# Altium schematic sheet Power_supply.SchDoc
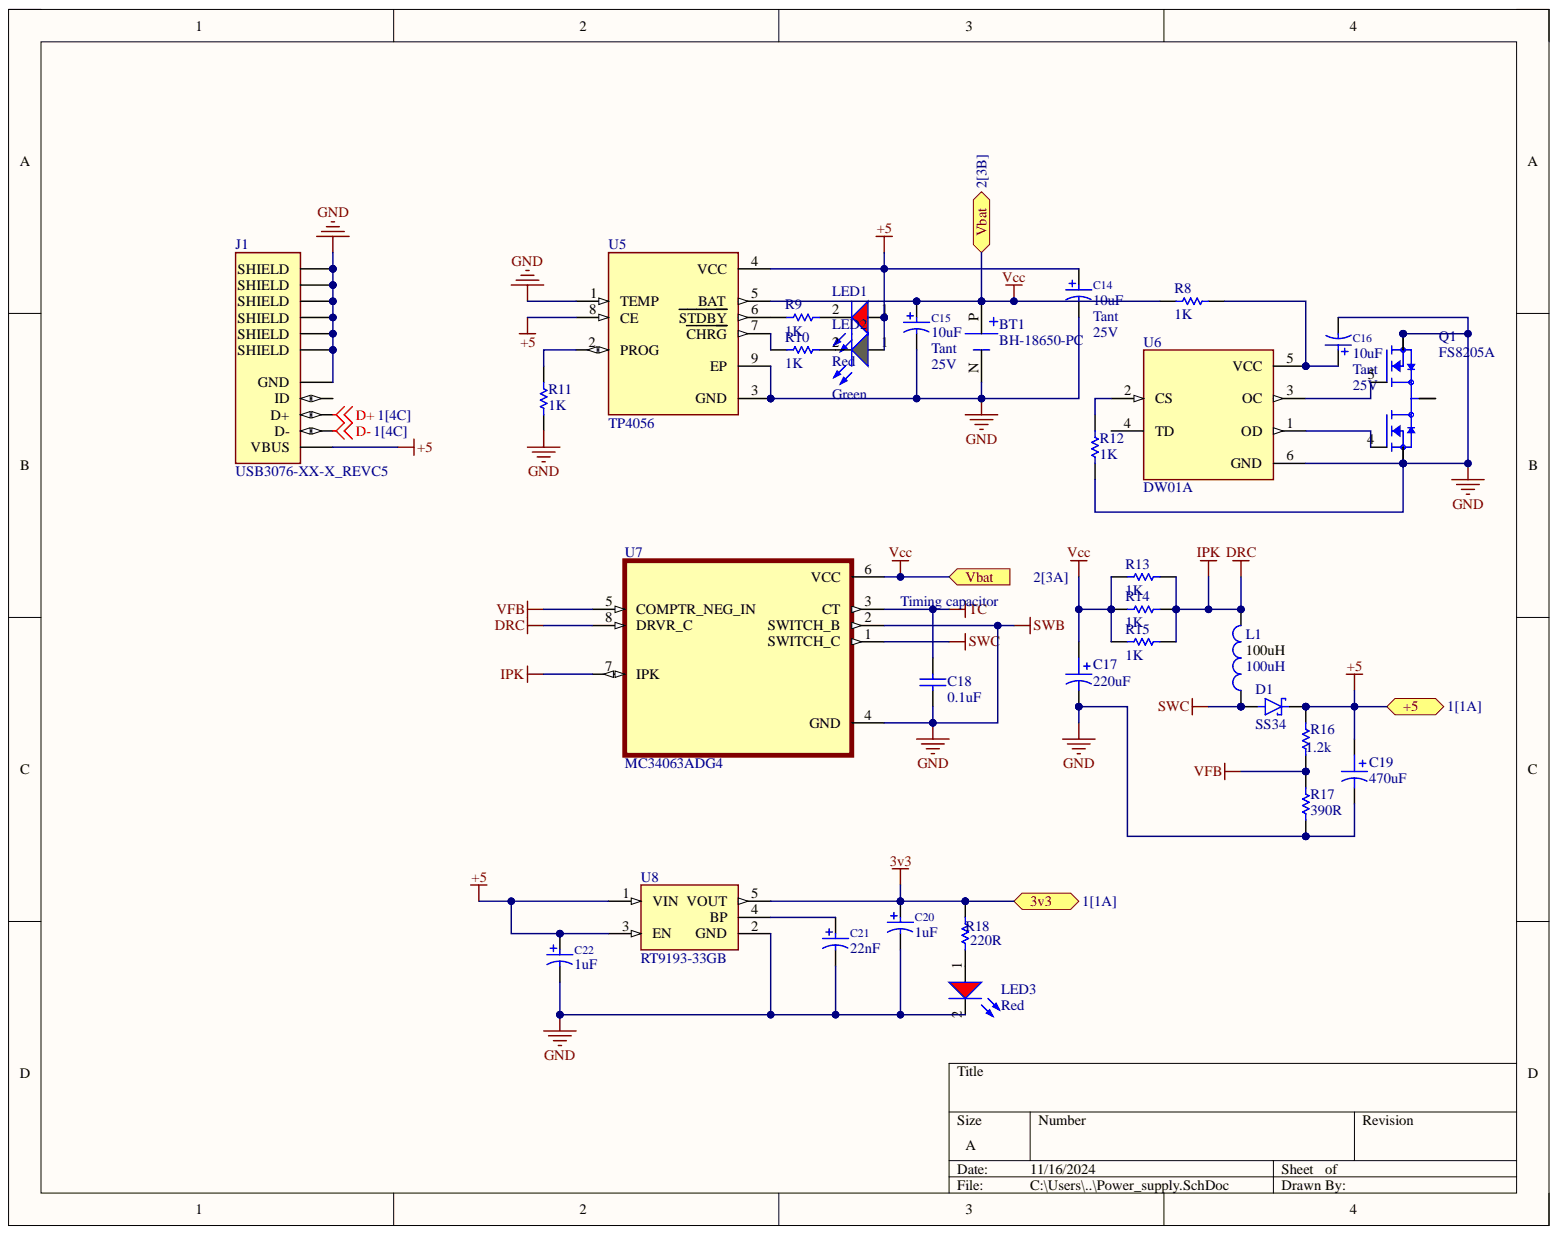
[diagram p0: Power_supply.SchDoc]

## Parts
BT1  BH-18650-PC  [BAT_BH-18650-PC]
C14  10uF  Capacitor Type: Tant  Volt: 25V  [C2012-0805]
C15  10uF  Capacitor Type: Tant  Volt: 25V  [C2012-0805]
C16  10uF  Capacitor Type: Tant  [C2012-0805]
C17  value 220uF  [RADIAL B]  (hidden comment: Cap Pol)
C18  value 0.1uF  [C-NP-0805]  (hidden comment: Cap Non-pol)
C19  value 470uF  [RADIAL C]  (hidden comment: Cap Pol)
C20  1uF  [C2012-0805]
C21  22nF  [C2012-0805]
C22  1uF  [C2012-0805]
D1  SS34  [DIOM7959X265N]
J1  USB3076-XX-X_REVC5  [GCT_USB3076-XX-X_REVC5]
L1  100uH  [IMPE8-RS2]
LED1  value Red  [Led 0805R]  (hidden comment: LED-0805RVC)
LED2  value Green  [Led 0805G]  (hidden comment: LED-0805GVC)
LED3  value Red  [Led 0805R]  (hidden comment: LED-0805RVC)
Q1  FS8205A  [SOP65P640X120-8N]
R8  value 1K  [RES-SM-0805]  (hidden comment: RESISTOR SMD)
R9  value 1K  [RES-SM-0805]  (hidden comment: RESISTOR SMD)
R10  value 1K  [RES-SM-0805]  (hidden comment: RESISTOR SMD)
R11  value 1K  [RES-SM-0805]  (hidden comment: RESISTOR SMD)
R12  value 1K  [RES-SM-0805]  (hidden comment: RESISTOR SMD)
R13  value 1K  [R-0805]  (hidden comment: RESISTOR)
R14  value 1K  [R-0805]  (hidden comment: RESISTOR)
R15  value 1K  [R-0805]  (hidden comment: RESISTOR)
R16  value 1.2k  [R-0805]  (hidden comment: RESISTOR)
R17  value 390R  [R-0805]  (hidden comment: RESISTOR)
R18  value 220R  [RES-SM-0805]  (hidden comment: RESISTOR SMD)
U5  TP4056  [SOP127P600X175-9N]
U6  DW01A  [SOT95P280X145-6N]
U7  MC34063ADG4  [SOIC127P599X175-8N]
U8  RT9193-33GB  [SOT94P279X129-5N]

## Ports
3v3, +5, Vbat

## Nets
D+: J1.3(D+)
D-: J1.2(D-)
DRC: L1.2 R13.2 R14.2 R15.2 U7.7(IPK) U7.8(DRVR_C)
GND: BT1.N C14.2 C15.2 C16.2 C17.2 C18.1 C19.2 C20.2 C21.2 C22.2 J1.5(GND) J1.6(SHIELD) J1.7(SHIELD) J1.8(SHIELD) J1.9(SHIELD) J1.10(SHIELD) J1.11(SHIELD) LED3.2 Q1.2 Q1.3 Q1.6 Q1.7 R11.1 R12.2 R17.1 U5.1(TEMP) U5.3(GND) U5.9(EP) U6.6(GND) U7.2(SWITCH_B) U7.4(GND) U8.2(GND)
SWC: D1.A L1.1 U7.1(SWITCH_C)
TC: C18.2 U7.3(CT)
VFB: R16.1 R17.2 U7.5(COMPTR_NEG_IN)
3v3: C20.1 R18.1 U8.5(VOUT) ; port 3v3
+5: C14.1 C19.1 C22.1 D1.C J1.1(VBUS) LED1.1 LED2.1 R16.2 U5.4(VCC) U5.8(CE) U8.1(VIN) U8.3(EN) ; port +5
Vcc: BT1.P C15.1 C17.1 R8.2 R13.1 R14.1 R15.1 U5.5(BAT) U7.6(VCC) ; port Vbat
NetC16_1: C16.1 R8.1 U6.5(VCC)
NetC21_1: C21.1 U8.4(BP)
NetLED1_2: LED1.2 R9.2
NetLED2_2: LED2.2 R10.1
NetLED3_1: LED3.1 R18.2
NetQ1_1: Q1.1 Q1.8
NetQ1_4: Q1.4 U6.1(OD)
NetQ1_5: Q1.5 U6.3(OC)
NetR9_1: R9.1 U5.6(STDBY)
NetR10_2: R10.2 U5.7(CHRG)
NetR11_2: R11.2 U5.2(PROG)
NetR12_1: R12.1 U6.2(CS)

Not connected: J1 4(ID); U6 4(TD)

## Library data of the parts
BT1 = BH-18650-PC: Battery Holder _Open_ 18650 1 Cell PC Pin; MF: MPD _Memory; Availability: Unavailable; Component Kind: Standard; Library Name: BH-18650-PC.IntLib; MP: BH-18650-PC; Package: DFN-8 Memory Protection Devices; Price: None; SnapEDA_Link: https://www.snapeda.com/parts/BH-18650-PC/MPD%20%28Memory%20Protection%20Devices%29/view-part/779074/?ref=snap
C14 C15 C20-C22 = Cap_Pol: Polarized Capacitor; Manufacturer: FARNELL; AEMS Code: 2100110; Vendor: Digikey; Component Kind: Standard; ComponentLink1Description: Digikey Part Link; ComponentLink2Description: Open Datasheet; Published: 13-April-2009; ComponentLink2URL: H:\LIBRARY\LIBRARY.Datasheet\; Library Name: PhongLib.IntLib; Publisher: Hoang Nguyen
  C14 C15: Value: 10uF
  C20 C22: Value: 1uF
  C21: Value: 22nF
C16 = Cap_Pol: Polarized Capacitor; Manufacturer: FARNELL; AEMS Code: 2100110; Vendor: Digikey; Volt: 25V; Component Kind: Standard; ComponentLink1Description: Digikey Part Link; ComponentLink2Description: Open Datasheet; Published: 13-April-2009; Value: 10uF; ComponentLink2URL: H:\LIBRARY\LIBRARY.Datasheet\; Library Name: PhongLib.IntLib; Publisher: Hoang Nguyen
C17 C19 = Cap Pol: Polarized Capacitor; Component Kind: Standard; LatestRevisionDate: 17-Jul-2002; LatestRevisionNote: Re-released for DXP Platform.; PackageReference: POLAR0.8; Published: 8-Jun-2000; Library Name: Kiet.IntLib; Publisher: Altium Limited
C18 = Cap Non-pol: Non-polarized Capacitor; Component Kind: Standard; LatestRevisionDate: 17-Jul-2002; LatestRevisionNote: Re-released for DXP Platform.; PackageReference: RAD-0.3; Published: 8-Jun-2000; Library Name: Kiet.IntLib; Publisher: Altium Limited
D1 = SS34: Diode Schottky 40 V 3A Surface Mount SMC (DO-214AB); MF: onsemi; Check_prices: https://www.snapeda.com/parts/SS34/Onsemi/view-part/?ref=eda; Component Kind: Standard; Library Name: SS34.IntLib; MP: SS34; Package: SMC-2 ON Semiconductor; SnapEDA_Link: https://www.snapeda.com/parts/SS34/Onsemi/view-part/?ref=snap
J1 = USB3076-XX-X_REVC5: USB - micro B USB 2.0 Receptacle Connector 5 Position Surface Mount, Right Angle; Through Hole, 2.35mm profile, 5 Pins, Horizontal, Bottom mount; MF: Global Connector Technology; MP: USB3076-30-A; Availability: In Stock; Check_prices: https://www.snapeda.com/parts/USB3076-30-A/Global+Connector+Technology/view-part/?ref=eda; Component Kind: Standard; Library Name: USB3076-XX-X_REVC5.IntLib; Package: None; Price: None; Purchase-URL: https://pricing.snapeda.com/search/part/USB3076-30-A/?ref=eda; SnapEDA_Link: https://www.snapeda.com/parts/USB3076-30-A/Global+Connector+Technology/view-part/?ref=snap
L1 = Inductor_1: Cuon Cam L; Code_IEC: 1005; Vendor: Digikey; Code_JEITA: 0402; Component Kind: Standard; ComponentLink1Description: Digikey Part Link; ComponentLink2Description: Open Datasheet; LatestRevisionDate: 17-Jul-2002; LatestRevisionNote: Re-released for DXP Platform.; PackageReference: 0402-A; Published: 8-Jun-2000; Signal Integrity: Inductor; Value: 100uH; ComponentLink2URL: H:\LIBRARY\LIBRARY.Datasheet\; Library Name: Kiet.IntLib; Publisher: Altium Limited
LED1 LED3 = LED-0805RVC: LED Red 2mA 1.8V 0805; Component Kind: Standard; ComponentLink1Description: Datasheet; Unit Price: 0.0476; ComponentLink1URL: D:\Dropbox\Datasheets\Opto and Illumination\LEDs\SMD LED.pdf; Library Name: thuvienhoang.IntLib
LED2 = LED-0805GVC: LED Green 2mA 3V 0805; Component Kind: Standard; ComponentLink1Description: Datasheet; Unit Price: 0.0476; ComponentLink1URL: D:\Dropbox\Datasheets\Opto and Illumination\LEDs\SMD LED.pdf; Library Name: thuvienhoang.IntLib
Q1 = FS8205A: N/A; MF: Fortune Semiconductor; Availability: Not in stock; Check_prices: https://www.snapeda.com/parts/FS8205A/Fortune+Semiconductor/view-part/?ref=eda; Component Kind: Standard; Library Name: FS8205A.IntLib; MP: FS8205A; Package: Package; Price: None; SnapEDA_Link: https://www.snapeda.com/parts/FS8205A/Fortune+Semiconductor/view-part/?ref=snap
R8 R10-R12 R18 = RESISTOR SMD: Resistor; Component Kind: Standard; Library Name: thuvienhoang.IntLib
R9 = RESISTOR SMD: Resistor; Value: 1K; Component Kind: Standard; Library Name: thuvienhoang.IntLib
U5 = TP4056: Complete single cell Li-Ion battery with a constant current / constant voltage linear charger; MF: NanJing Top Power ASIC Corp.; Availability: Not in stock; Check_prices: https://www.snapeda.com/parts/TP4056/NanJing+Top+Power+ASIC+Corp./view-part/?ref=eda; Component Kind: Standard; Library Name: TP4056.IntLib; MP: TP4056; Package: Package; Price: None; SnapEDA_Link: https://www.snapeda.com/parts/TP4056/NanJing+Top+Power+ASIC+Corp./view-part/?ref=snap
U6 = DW01A: One Cell Lithium-ion/Polymer Battery Protection IC; MF: Fortune Semiconductor; Check_prices: https://www.snapeda.com/parts/DW01A/Fortune+Semiconductor/view-part/?ref=eda; Component Kind: Standard; Library Name: DW01A.IntLib; MP: DW01A; Package: SOT-23-6 Fortune Semiconductor; SnapEDA_Link: https://www.snapeda.com/parts/DW01A/Fortune+Semiconductor/view-part/?ref=snap
U8 = RT9193-33GB: Linear Voltage Regulator IC Positive Fixed 1 Output 300mA TSOT-23-5; MF: Richtek USA; Check_prices: https://www.snapeda.com/parts/RT9193-33GB/Richtek+USA+Inc./view-part/?ref=eda; Component Kind: Standard; Digi-Key_Part_Number: 1028-1014-2-ND; Library Name: RT9193-33GB.IntLib; MP: RT9193-33GB; Package: SOT-23-5 Richtek; Purchase-URL: https://pricing.snapeda.com/search/part/RT9193-33GB/?ref=eda; SnapEDA_Link: https://www.snapeda.com/parts/RT9193-33GB/Richtek+USA+Inc./view-part/?ref=snap
R13-R17 = RESISTOR: Component Kind: Standard; Description: Resistor; LatestRevisionDate: 17-Jul-2002; LatestRevisionNote: Re-released for DXP Platform.; PackageReference: AXIAL-0.3; Published: 8-Jun-2000; Library Name: Kiet.IntLib; Publisher: Altium Limited
U7 = MC34063ADG4: Availability: Unavailable; Component Kind: Standard; Library Name: MC34063ADG4.IntLib; MP: MC34063ADG4; Package: None; Price: None
